# Altium schematic sheet Sheet1.SchDoc
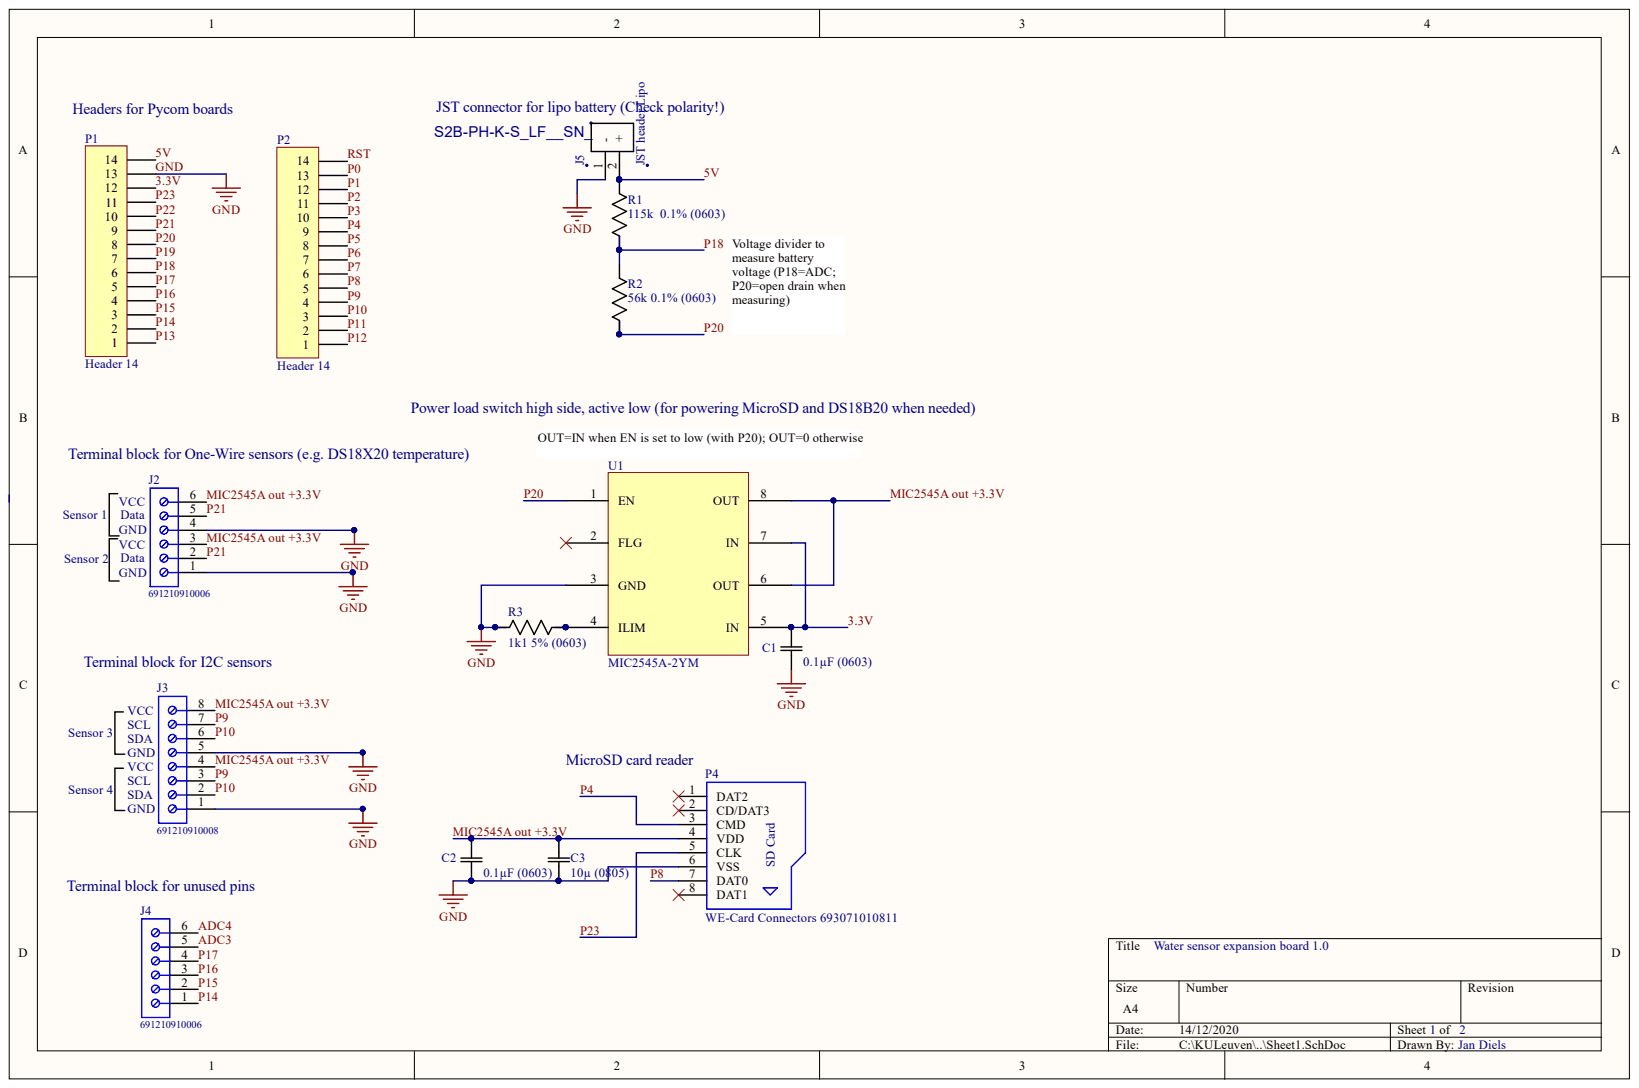
[diagram p0: Sheet1.SchDoc]

## Parts
C1  0.1µF (0603)  [cap_UMK107ABJ474]
C2  0.1µF (0603)  [cap_UMK107ABJ474]
C3  10µ (0805)  value 10µ  [CAP_LMK212AB7100]
J2  691210910006  [691210910006]
J3  691210910008  [691210910008]
J4  691210910006  [691210910006]
J5  JST header Lipo  MP: S2B-PH-K-S_LF__SN_  [JST_S2B-PH-K-S(LF)(SN)]
P1  Header 14  [HDR1X14]
P2  Header 14  [HDR1X14]
P4  WE-Card Connectors 693071010811  [693071010811]
R1  115k  0.1% (0603)  value 115k  [RC0603N]
R2  56k 0.1% (0603)  value 56k  [RC0603N]
R3  1k1 5% (0603)  [RC0603N]
U1  MIC2545A-2YM  [SOIC127P599X175-8N]

## Nets
3.3V: C1.2 P1.12 U1.5(IN) U1.7(IN)
5V: J5.2 P1.14 R1.1
ADC3: J4.5
ADC4: J4.6
GND: C1.1 C2.1 C3.1 J2.1 J2.4 J3.1 J3.5 J5.1 P1.13 P4.6(VSS) R3.1 U1.3(GND)
MIC2545A OUT +3.3V: C2.2 C3.2 J2.3 J2.6 J3.4 J3.8 P4.4(VDD) U1.6(OUT) U1.8(OUT)
P0: P2.13
P1: P2.12
P2: P2.11
P3: P2.10
P4: P2.9 P4.3(CMD)
P5: P2.8
P6: P2.7
P7: P2.6
P8: P2.5 P4.7(DAT0)
P9: J3.3 J3.7 P2.4
P10: J3.2 J3.6 P2.3
P11: P2.2
P12: P2.1
P13: P1.1
P14: J4.1 P1.2
P15: J4.2 P1.3
P16: J4.3 P1.4
P17: J4.4 P1.5
P18: P1.6 R1.2 R2.1
P19: P1.7
P20: P1.8 R2.2 U1.1(EN)
P21: J2.2 J2.5 P1.9
P22: P1.10
P23: P1.11 P4.5(CLK)
RST: P2.14
NetR3_2: R3.2 U1.4(ILIM)
N00007:

Not connected: P4 1(DAT2) 2(CD/DAT3) 8(DAT1); U1 2(FLG)

## Library data of the parts
C1 C2 = EMK107B7105KAHT: 0.1uF MLCC  16V X7R 10% 0603; Built_By: EMA_UL_Team; Type: DEV; Value: 0.1µ; Vendor: Taiyo Yuden; Component Kind: Standard; Library Name: OpenWater1.SchLib; Manufacturer_Part_Number: EMK107B7105KAHT; RefDes: RefDes; Copyright: Copyright (C) 2018 Accelerated Designs. All rights reserved
C3 = LMK212AB7106MG-T: 10uF Multilayer Ceramic Capacitors MLCC - SMD/SMT 0805 10VDC  20% X7R; Built_By: EMA_UL_Team; Type: DEV; Vendor: Taiyo Yuden; Component Kind: Standard; Library Name: OpenWater1.SchLib; Manufacturer_Part_Number: LMK212AB7106MG-T; RefDes: RefDes; Copyright: Copyright (C) 2018 Accelerated Designs. All rights reserved
J2 J4 = 691210910006: Serie 2109 - 2.54 mm Horizontal Entry with Rising Cage Clamp WR-TBL, 6 pin; Pin Number: 6; Pitch: 2.54 mm; Component Kind: Standard; ComponentLink1Description: Manufacturer; ComponentLink1URL: http://www.we-online.de; ComponentLink2Description: Datasheet; ComponentLink2URL: http://katalog.we-online.de/em/datasheet/6912109100xx.pdf; Library Name: Altium_TBL_RISING_CAGE_SYSTEM (rev18b).IntLib; Mounting Technology: THT; Operating Temperature: -40 to 105 degC; Packaging: Box; Part Number: 691210910006; Published Date: June 2018; Publisher: Wurth Elektronik
J3 = 691210910008: Serie 2109 - 2.54 mm Horizontal Entry with Rising Cage Clamp WR-TBL, 8 pin; Pin Number: 8; Pitch: 2.54 mm; Component Kind: Standard; ComponentLink1Description: Manufacturer; ComponentLink1URL: http://www.we-online.de; ComponentLink2Description: Datasheet; ComponentLink2URL: http://katalog.we-online.de/em/datasheet/6912109100xx.pdf; Library Name: Altium_TBL_RISING_CAGE_SYSTEM (rev18b).IntLib; Mounting Technology: THT; Operating Temperature: -40 to 105 degC; Packaging: Box; Part Number: 691210910008; Published Date: June 2018; Publisher: Wurth Elektronik
J5 = S2B-PH-K-S(LF)(SN): JST connector PH Series 2 Position 2.0 mm Through Hole Side Entry Shrouded Header; MF: JST Sales; Component Kind: Standard; Digi-Key_Part_Number: 455-1719-ND; Digi-Key_Purchase_URL: https://www.digikey.com/product-detail/en/jst-sales-america-inc/S2B-PH-K-S_LF__SN_/455-1719-ND/926626?utm_source=snapeda&utm_medium=aggregator&utm_campaign=symbol; Library Name: OpenWater1.SchLib; Package: None; RS-Stocknr.: 820-1494; SnapEDA_Link: https://www.snapeda.com/parts/S2B-PH-K-S%28LF%29%28SN%29/JST%20Sales%20America%20Inc./view-part/?ref=snap
P1 P2 = Header 14: Header, 14-Pin; Component Kind: Standard; LatestRevisionDate: 17-Jul-2002; LatestRevisionNote: Re-released for DXP Platform.; Library Name: OpenWater1.SCHLIB; Publisher: Altium Limited
P4 = WE-Card Connectors 693071010811: WR-CRD Micro SD_Push and Push; Manufacturer: Würth Elektronik eiSos GmbH; ComponentLink1 Description: Manufacturer; ComponentLink2 Description: Datasheet; NoPins: 8; Component Kind: Standard; ComponentLink1URL: http://www.we-online.de; ComponentLink2URL: http://katalog.we-online.de/em/datasheet/693071010811.pdf; Created Date: 10/10/2014; Library Name: OpenWater1.SchLib; partNo: 693071010811; Created by: Karrer Zheng
R1 = ERA-3AEB101V: 115Kohm 0.1% Thin Film Resistor - SMD 0603  25ppm; Manufacturer_Part_Number: ERA-3AEB1153V; Built_By: EMA_UL_Team; Vendor: Panasonic; Component Kind: Standard; Library Name: OpenWater1.SchLib; RefDes: RefDes; Type: DEV; Copyright: Copyright (C) 2018 Accelerated Designs. All rights reserved
R2 = ERA-3AEB563V: 56Kohms 0.1% Thin Film Resistor - SMD 0603 1/10W; Built_By: EMA_UL_Team; Vendor: Panasonic; Component Kind: Standard; Library Name: OpenWater1.SchLib; Manufacturer_Part_Number: ERA-3AEB563V; RefDes: RefDes; Type: DEV; Copyright: Copyright (C) 2018 Accelerated Designs. All rights reserved
R3 = CRCW06031K00DHEA: 1.1Kohms 5% Thick Film Resistor - SMD 1/10Watt  Commercial Use; Manufacturer_Part_Number: CRCW06031K10JNEAC; Built_By: EMA_UL_Team; Vendor: Vishay; Component Kind: Standard; Library Name: OpenWater1.SchLib; RefDes: RefDes; Type: DEV; Value: Value; Copyright: Copyright (C) 2018 Accelerated Designs. All rights reserved
U1 = MIC2545A-2YM: Programmable Current Limit Single High-Side Switch; Manufacturer: Microchip Technology / Micrel; NoPins: 8; Component Kind: Standard; Library Name: OpenWater1.SchLib; PartNo: MIC2545A-2YM
